# Altium schematic sheet Schematico_Pompa.SchDoc
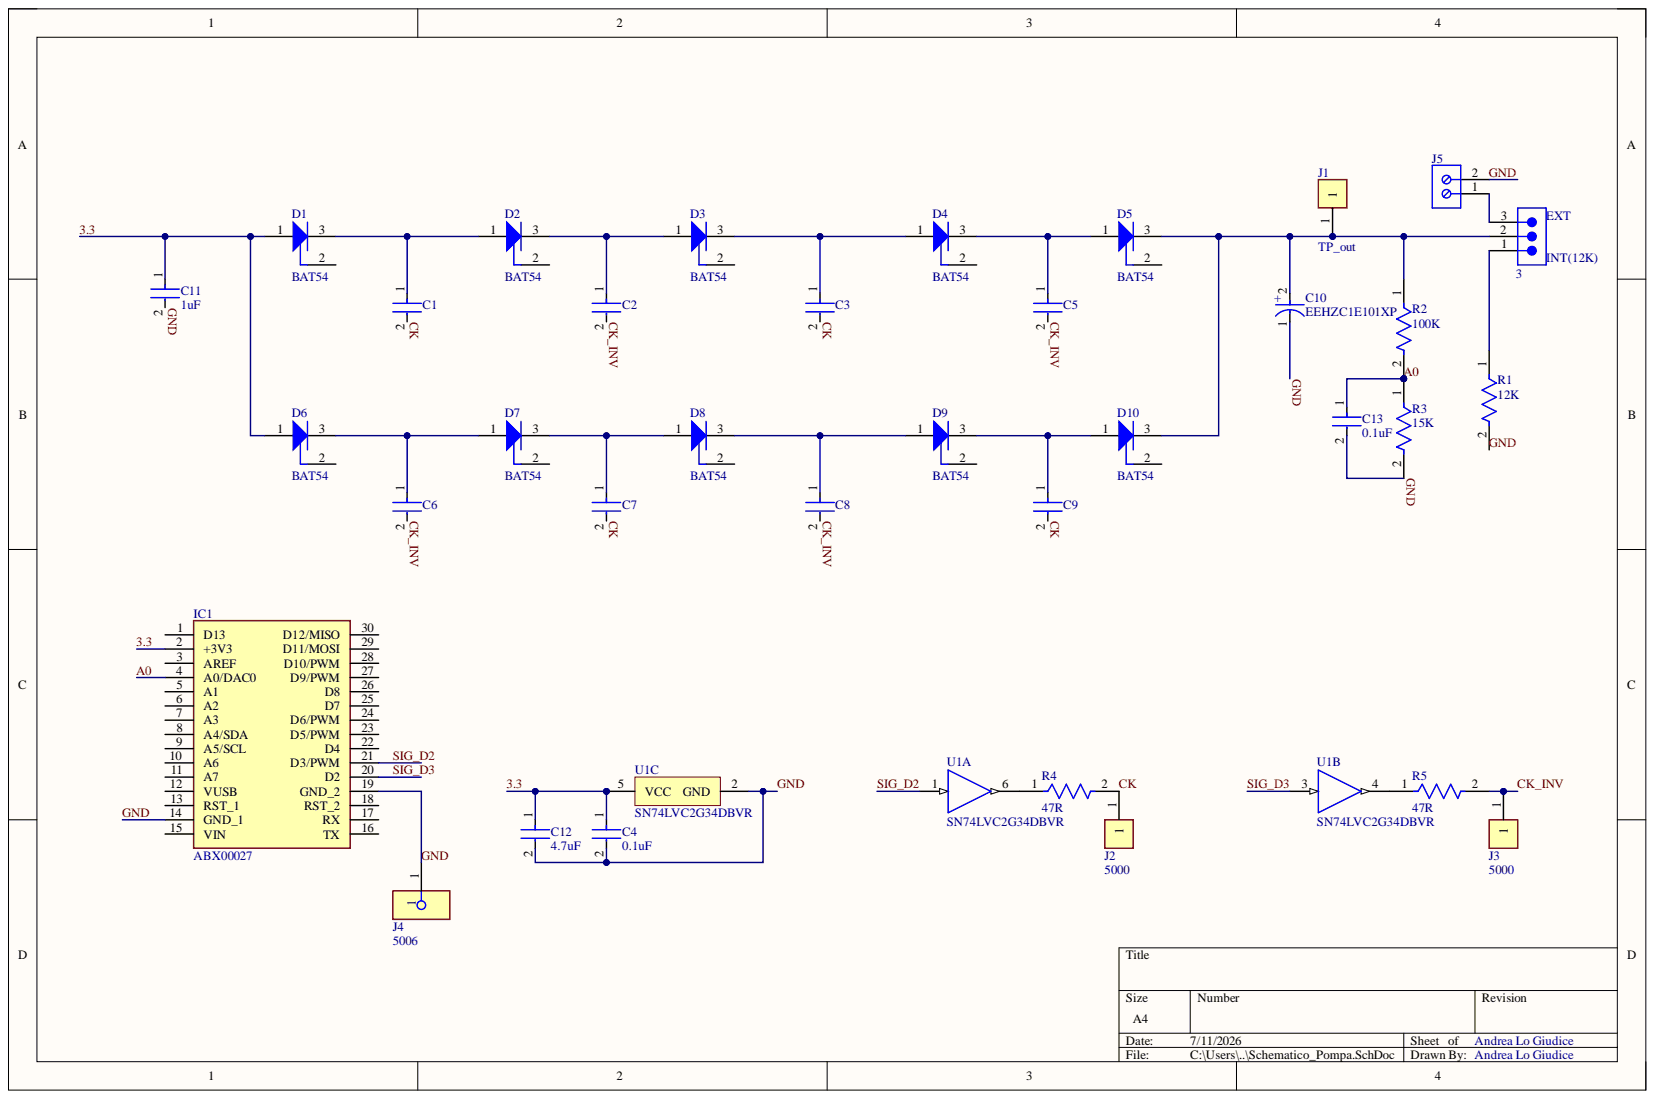
[diagram p0: Schematico_Pompa.SchDoc]

## Parts
3  TSW-103-07-T-S  [SMTC-TSW-103-07-X-S]  (value not drawn)
C1  GRM188Z71E225ME43D  [FP-GRM188-0_2-IPC_C]  (value not drawn)
C2  GRM188Z71E225ME43D  [FP-GRM188-0_2-IPC_C]  (value not drawn)
C3  GRM188Z71E225ME43D  [FP-GRM188-0_2-IPC_C]  (value not drawn)
C4  0.1uF  [FP-C0603C-CF-IPC_B]
C5  GRM188Z71E225ME43D  [FP-GRM188-0_2-IPC_C]  (value not drawn)
C6  GRM188Z71E225ME43D  [FP-GRM188-0_2-IPC_C]  (value not drawn)
C7  GRM188Z71E225ME43D  [FP-GRM188-0_2-IPC_C]  (value not drawn)
C8  GRM188Z71E225ME43D  [FP-GRM188-0_2-IPC_C]  (value not drawn)
C9  GRM188Z71E225ME43D  [FP-GRM188-0_2-IPC_C]  (value not drawn)
C10  EEHZC1E101XP  [FP-EEH-D8-MFG]
C11  1uF  [FP-CC0603-DA-IPC_B]
C12  4.7uF  [FP-CC0603-DA-IPC_B]
C13  0.1uF  [FP-C0603C-CF-IPC_B]
D1  BAT54  [INF-SOT23_L]
D2  BAT54  [INF-SOT23_L]
D3  BAT54  [INF-SOT23_L]
D4  BAT54  [INF-SOT23_L]
D5  BAT54  [INF-SOT23_L]
D6  BAT54  [INF-SOT23_L]
D7  BAT54  [INF-SOT23_L]
D8  BAT54  [INF-SOT23_L]
D9  BAT54  [INF-SOT23_L]
D10  BAT54  [INF-SOT23_L]
IC1  ABX00027  [ABX00027]
J1  TP_out  [FP-5000-MFG]
J2  5000  [FP-5000-MFG]
J3  5000  [FP-5000-MFG]
J4  5006  [FP-5006-MFG]
J5  691214110002  [691214110002]  (value not drawn)
R1  12K  [FP-AT0603-IPC_C]
R2  100K  [FP-CRGP0603-IPC_A]
R3  15K  [FP-AC0603-IPC_C]
R4  47R  [FP-AC0603-IPC_C]
R5  47R  [FP-AC0603-IPC_C]
U1  SN74LVC2G34DBVR  [DBV0006A_N]  (parts A,B,C)

## Nets
3.3: C4.1 C11.1 C12.1 D1.1 D6.1 IC1.2(+3V3) U1.5(VCC)
A0: C13.1 IC1.4(A0/DAC0) R2.2 R3.1
CK: C1.2 C3.2 C7.2 C9.2 J2.1 R4.2
CK_INV: C2.2 C5.2 C6.2 C8.2 J3.1 R5.2
GND: C4.2 C10.1 C11.2 C12.2 C13.2 IC1.14(GND_1) IC1.19(GND_2) J4.1 J5.2 R1.2 R3.2 U1.2(GND)
SIG_D2: IC1.21(D3/PWM) U1.1
SIG_D3: IC1.20(D2) U1.3
Net3_1: 3.1 R1.1
Net3_2: 3.2 C10.2 D5.3 D10.3 J1.1 R2.1
Net3_3: 3.3 J5.1
NetC1_1: C1.1 D1.3 D2.1
NetC2_1: C2.1 D2.3 D3.1
NetC3_1: C3.1 D3.3 D4.1
NetC5_1: C5.1 D4.3 D5.1
NetC6_1: C6.1 D6.3 D7.1
NetC7_1: C7.1 D7.3 D8.1
NetC8_1: C8.1 D8.3 D9.1
NetC9_1: C9.1 D9.3 D10.1
NetR4_1: R4.1 U1.6
NetR5_1: R5.1 U1.4

Not connected: D1 2; D2 2; D3 2; D4 2; D5 2; D6 2; D7 2; D8 2; D9 2; D10 2; IC1 1(D13) 3(AREF) 5(A1) 6(A2) 7(A3) 8(A4/SDA) 9(A5/SCL) 10(A6) 11(A7) 12(VUSB) 13(RST_1) 15(VIN) 16(TX) 17(RX) 18(RST_2) 22(D4) 23(D5/PWM) 24(D6/PWM) 25(D7) 26(D8) 27(D9/PWM) 28(D10/PWM) 29(D11/MOSI) 30(D12/MISO)

## Library data of the parts
3 = CMP-1024-00332-1: 0.025" SQ Post Header, Through-hole, Vertical, -55 to 105 degC, 2.54 mm Pitch, 3-Pin, Male, RoHS; Manufacturer: Samtec; Pitch: 2.54 mm; Component Kind: Standard; ComponentLink1Description: Manufacturer URL; ComponentLink1URL: http://www.samtec.com; ComponentLink2Description: Datasheet; ComponentLink2URL: http://www.samtec.com/documents/webfiles/pdf/TSW_TH.PDF; ComponentLink3Description: Package Specification; ComponentLink3URL: http://www.samtec.com/documents/webfiles/cpdf/TSW-XXX-XX-XXX-X-XX-XXX-MKT.pdf; DatasheetVersion: F-214; Library Name: Altium Content Vault; Mounting Technology: Through-hole; Orientation: Vertical; PackageDescription: .025[0.64] SQ. Post Terminal Strip Assembly; PackageReference: TSW-103-07-X-S; PackageVersion: DM, 8/1988; PartNumber: TSW-103-07-T-S; RoHS: True; Temperature Range: -55 to 105 degC
C1-C3 C5-C9 = CMP-06035-003326-1: Chip Multilayer Ceramic Capacitors for General Purpose, 0603, 2.2uF, X7R, 15%, 20%, 25V; Component Kind: Standard; Library Name: Altium Content Vault
C4 C13 = CMP-2006-03067-2: CAP CER 0.1UF 16V X7R 0603; Manufacturer: KEMET; Manufacturer Part Number: C0603C104M4RACTU; Automotive Grade: Grade 1; Capacitance: 0.10 μF; Material: Ceramic; Temperature Coefficient: 30ppm/°C; Tolerance: 0.2; Value: 0.10 μF; Automotive: Yes; Category: Cap; Component Kind: Standard; ComponentLink2Description: Datasheet; ComponentLink2URL: https://api.kemet.com/component-edge/download/datasheet/C0603C100J5GACAUTO.pdf; Device Class L1: Passive Components; Device Class L2: Capacitors; Device Class L3: Ceramic Capacitors; Digikey Description: CAP CER 0.1UF 16V X7R 0603; Height: 0.87mm; Lead Free: Yes; Library Name: Altium Content Vault; Mouser Description: Multilayer Ceramic Capacitors MLCC - SMD/SMT 16volts 0.1uF X7R 20%; Octopart: https://octopart.com/c0603c104m4ractu-kemet-134275; Package: C0603C-CF; RoHS: TRUE; Temperature: -55°C to +125°C; Temperature Characteristic: X7R; Temperature Range High: +125°C; Temperature Range Low: -55°C; Voltage Rating: 16 V
C10 = CMP-05428-000858-1: Conductive Polymer Hybrid Aluminum Electrolytic Capacitors 100uF ±20% 25V RADIAL SMT 6.3x7.7mm; ESR (Equivalent Series Resistance): 30mR; Lead Diameter: 0.65mm; Leakage Current: 3uA; Life (Hours): 4000Hour; Ripple Current: 1.4A; Capacitance: 100uF; Case/Package: Radial; Component Kind: Standard; Construction: Cylindrical; Diameter: 6.3mm; Height: 7.7mm; Height - Seated (Max): 0.315inch; Lead Free: Lead Free; Lead Pitch: 1.8mm; Length: 7.7mm; Library Name: Altium Content Vault; Material: Polymer; Max Operating Temperature: 125°C; Min Operating Temperature: -55°C; Mount: Surface Mount; Number of Pins: 8; Package Quantity: 900; Packaging: Tape and Reel; Radiation Hardening: No; Ratings: AEC-Q200; REACH SVHC: No SVHC; Ripple Current (AC): 1.4A; RoHS Compliant: Yes; Series: ZC; Technology: HYBRID; Termination: SMD/SMT; Tolerance: 20%; Voltage: 25V; Voltage Rating: 25V; Voltage Rating (DC): 25V
C11 = CMP-03422-000101-1: CC0603 1 uF X7R 10.00% 25 V; Manufacturer: YAGEO; Manufacturer Part Number: CC0603KPX7R8BB105; Material: Ceramic; Temperature Coefficient: 15%; Tolerance: 0.1; Voltage: 25 V; Automotive: No; Capacitance: 1 uF; Category: Cap; Component Kind: Standard; ComponentLink2Description: Datasheet; ComponentLink2URL: http://www.yageo.com/documents/recent/UPY-GPHC_X7R_6.3V-to-50V_18.pdf; Device Class L1: Passive Components; Device Class L2: Capacitors; Device Class L3: Ceramic Capacitors; Footprint Url: http://www.yageo.com/exep/pages/download/literatures/UPY-C_GEN_24.pdf; Height: 0.9mm; Lead Free: Yes; Library Name: Altium Content Vault; Octopart: https://octopart.com/cc0603kpx7r8bb105-yageo-95714672; Package: CC0603-DA; RoHS: TRUE; Temperature: -55°C to +125°C; Temperature Characteristic: X7R; Temperature Range High: +125°C; Temperature Range Low: -55°C; Value: 1 uF; Voltage Rating: 25 V
C12 = CMP-03422-001204-1: CAP CER 4.7UF 25V X5R 0603; Manufacturer: YAGEO; Manufacturer Part Number: CC0603MRX5R8BB475; Material: Ceramic; Temperature Coefficient: 15%; Tolerance: 0.2; Automotive: No; Capacitance: 4.7 uF; Category: Cap; Component Kind: Standard; ComponentLink2Description: Datasheet; ComponentLink2URL: http://www.yageo.com/documents/recent/UPY-GPHC_X7R_6.3V-to-50V_18.pdf; Device Class L1: Passive Components; Device Class L2: Capacitors; Device Class L3: Ceramic Capacitors; Digikey Description: CAP CER 4.7UF 25V X5R 0603; Footprint Url: http://www.yageo.com/exep/pages/download/literatures/UPY-C_GEN_24.pdf; Height: 0.9mm; Lead Free: Yes; Library Name: Altium Content Vault; Octopart: https://octopart.com/cc0603mrx5r8bb475-yageo-81932637; Package: CC0603-DA; RoHS: TRUE; Temperature: -55°C to +125°C; Temperature Characteristic: X5R; Temperature Range High: +125°C; Temperature Range Low: -55°C; Value: 4.7 uF; Voltage: 25 V; Voltage Rating: 25 V
D1-D10 = CMP-1182-00010-1: Silicon Schottky Diode, SOT23, Reel, Green; Manufacturer: Infineon; Configuration: Single; CT (max): 10Â pF; Green: TRUE; IF (max): 200Â mA; VF (max): 800Â mV; VR (max): 30Â V; Component Kind: Standard; ComponentLink1Description: Manufacturer URL; ComponentLink1URL: http://www.infineon.com/; ComponentLink2Description: Datasheet; ComponentLink2URL: http://www.infineon.com/dgdl/bat54series.pdf?folderId=db3a30431400ef68011425f1ca2505e3&fileId=db3a304314dca3890115190b74210ea6; ComponentLink3Description: Package Specification; ComponentLink3URL: http://www.infineon.com/dgdl/SOT23-Package_Overview.pdf?folderId=db3a30431936bc4b0119532290053c66&fileId=db3a30431936bc4b0119533468123c85; Library Name: Altium Content Vault; Mounting Technology: Surface Mount; PackageDescription: 3-Pin Small Outline Transistor Package, 1.3 x 2.9 mm Body, 0.95 mm Pitch; PackageReference: SOT23; PackageVersion: Apr-08; Packing: Reel; PartNumber: BAT54
IC1 = ABX00027: Integrated Circuit; Manufacturer_Name: Arduino; Arrow Part Number: ABX00027; Arrow Price/Stock: https://www.arrow.com/en/products/abx00027/arduino-corporation.html?utm_currency=USD&region=nac; Component Kind: Standard; Datasheet Link: https://docs.arduino.cc/static/41e9116cd2cb96a5d1375d64250b334c/ABX00027-datasheet.pdf; Library Name: SamacSys.SchLib; Manufacturer_Part_Number: ABX00027; Mouser Part Number: 782-ABX00027; Mouser Price/Stock: https://www.mouser.co.uk/ProductDetail/Arduino/ABX00027?qs=PzGy0jfpSMvleC8D9q4oLA%3D%3D
J1-J3 = CMP-19636-000027-1: PC TEST POINT MINIATURE RED; Body Material: Bronze; Hole Diameter: 1.6mm; Inside Diameter: 1.27mm; Insulation: Insulated; Number of Contacts: 1; Outside Diameter: 2.54mm; Plating: Silver; Color: Red; Component Kind: Standard; Contact Material: Bronze; Contact Plating: Tin; Flammability Rating: UL94 V-0; Height: 0.3inch; Housing Color: Red; Lead Free: Lead Free; Length: 7.62mm; Library Name: Altium Content Vault; Material: Nylon; Mount: PCB; Number of Ports: 1; Orientation: Straight; Package Quantity: 100; Packaging: Bulk; REACH SVHC: No SVHC; RoHS Compliant: Yes; Series: THM
J4 = CMP-19636-000022-1: PC TEST POINT COMPACT BLACK; Body Material: Bronze; Hole Diameter: 1.6mm; Inside Diameter: 1.78mm; Number of Ports: 1; Outside Diameter: 3.18mm; Plating: Silver; Color: Black; Component Kind: Standard; Contact Material: Bronze; Contact Plating: Tin; Flammability Rating: UL94 V-0; Height: 0.35inch; Housing Color: Black; Insulation Material: Nylon; Lead Free: Lead Free; Length: 8.89mm; Library Name: Altium Content Vault; Material: Nylon; Mount: PCB; Orientation: Straight; Package Quantity: 100; Packaging: Bulk; REACH SVHC: No SVHC; RoHS Compliant: Yes; Series: THM
J5 = CMP-1502-02464-3: 2141 Series 3.5MM Pitch Straight, Pcb Terminal Block, Through Hole, 2 Way; Current: 10A; Number of Contacts: 2; Number of Levels: 1; Pitch: 3.5052mm; Plating: Brass, Steel; Stripping Length: -6mm; Voltage: 300V; Wire Gauge (Max): 24AWG; Wire Gauge (Min): 16AWG; Color: Blue, Green; Component Kind: Standard; Contact Material: Tin; Contact Plating: Brass, Tin; Current Rating: 10A; Height: 8.7mm; Housing Material: Nylon; Lead Pitch: 3.5mm; Length: 7.4mm; Max Operating Temperature: 105°C; Min Operating Temperature: -40°C; Mount: Through Hole; Number of Pins: 2; Number of Positions Per Level: 2; Number of Rows: 1; Packaging: Box; RoHS: Compliant; Termination: Screw; Voltage Rating: 300V; Width: 7.9mm; Wire/Cable Gauge: 24AWG; Library Name: morsetto_vite.SchLib
R1 = CMP-03412-015386-2: Thin Film Chip Resistors Automotive Grade 12kΩ 0.5% 50ppm/°C 0603; Max Power Dissipation: 100mW; Resistance: 12kR; Case/Package: 0603; Component Kind: Standard; Features: Moisture Resistant; Height: 0.022inch; Length: 0.063inch; Library Name: Altium Content Vault; Max Operating Temperature: 155°C; Min Operating Temperature: -55°C; Number of Terminations: 2; Packaging: Tape and Reel; Ratings: AEC-Q200; Resistor Type: Thin Film; RoHS Compliant: Yes; Series: AT; Temperature Coefficient: 50ppm/°C; Tolerance: 0.5%; Width: 0.032inch
R2 = CMP-03211-000885-1: CRGP 0603 100K 1%; Manufacturer: TE; Manufacturer Part Number: CRGP0603F100K; Power Rating: 0.25W; Resistance: 100KΩ; Temperature Coefficient: ±100ppm/°C; Tolerance: 0.01; Value: 100KΩ; Voltage Rating: 50V; Ambient Temperature Range High: +70°C; Automotive: No; Category: Res; Component Kind: Standard; ComponentLink2Description: Datasheet; ComponentLink2URL: https://www.te.com/commerce/DocumentDelivery/DDEController?Action=srchrtrv&DocNm=9-1773463-9&DocType=DS&DocLang=English; Composition: Thick Film; Device Class L1: Passive Components; Device Class L2: Resistors; Device Class L3: Chip SMD Resistors; Digikey Description: CRGP 0603 100K 1%; Height: 0.55mm; Ipc Land Pattern Name: RESC16080X45; Lead Free: Yes; Library Name: Altium Content Vault; Octopart: https://octopart.com/crgp0603f100k-te+connectivity-91018719; Package: CRGP0603; RoHS: TRUE; Series: CRGP; Temperature: -55°C to +155°C; Temperature Range High: +155°C; Temperature Range Low: -55°C
R3 = CMP-03412-044984-1: RES 15kΩ 1.00% 0.2W 0603; Component Kind: Standard; Library Name: Altium Content Vault
R4 R5 = CMP-03412-038070-1: RES 47Ω 5.00% 0.1W 0603; Component Kind: Standard; Library Name: Altium Content Vault
U1 = CMP-0859-00223-3: Dual Buffer Gate, DBV0006A, LARGE T&R; Manufacturer: Texas Instruments; 3-State Output: No; Bits(#): 2; F @ Nom Voltage(Max)(Mhz): 150; ICC @ Nom Voltage(Max)(mA): 0.01; Input Type: CMOS/TTL; Logic: True; Output Drive (IOL/IOH)(Max)(mA): 32/-32; Output Type: CMOS; Rating: Catalog; Sub-Family: Non-Inverting Buffer/Driver; Technology Family: LVC; tpd @ Nom Voltage(Max)(ns): 8.6,4.4,4.1,3.2; VCC(Max)(V): 5.5; VCC(Min)(V): 1.65; Voltage(Nom)(V): 1.8,2.5,3.3,5; Component Kind: Standard; DatasheetVersion: SCES359I; Generic Part Number: SN74LVC2G34; Library Name: Altium Content Vault; Operating Temperature Range(C): -40 to 125,-40 to 85; PackageReference: DBV0006A; Schmitt Trigger: No
